# Altium schematic sheet USB.SchDoc
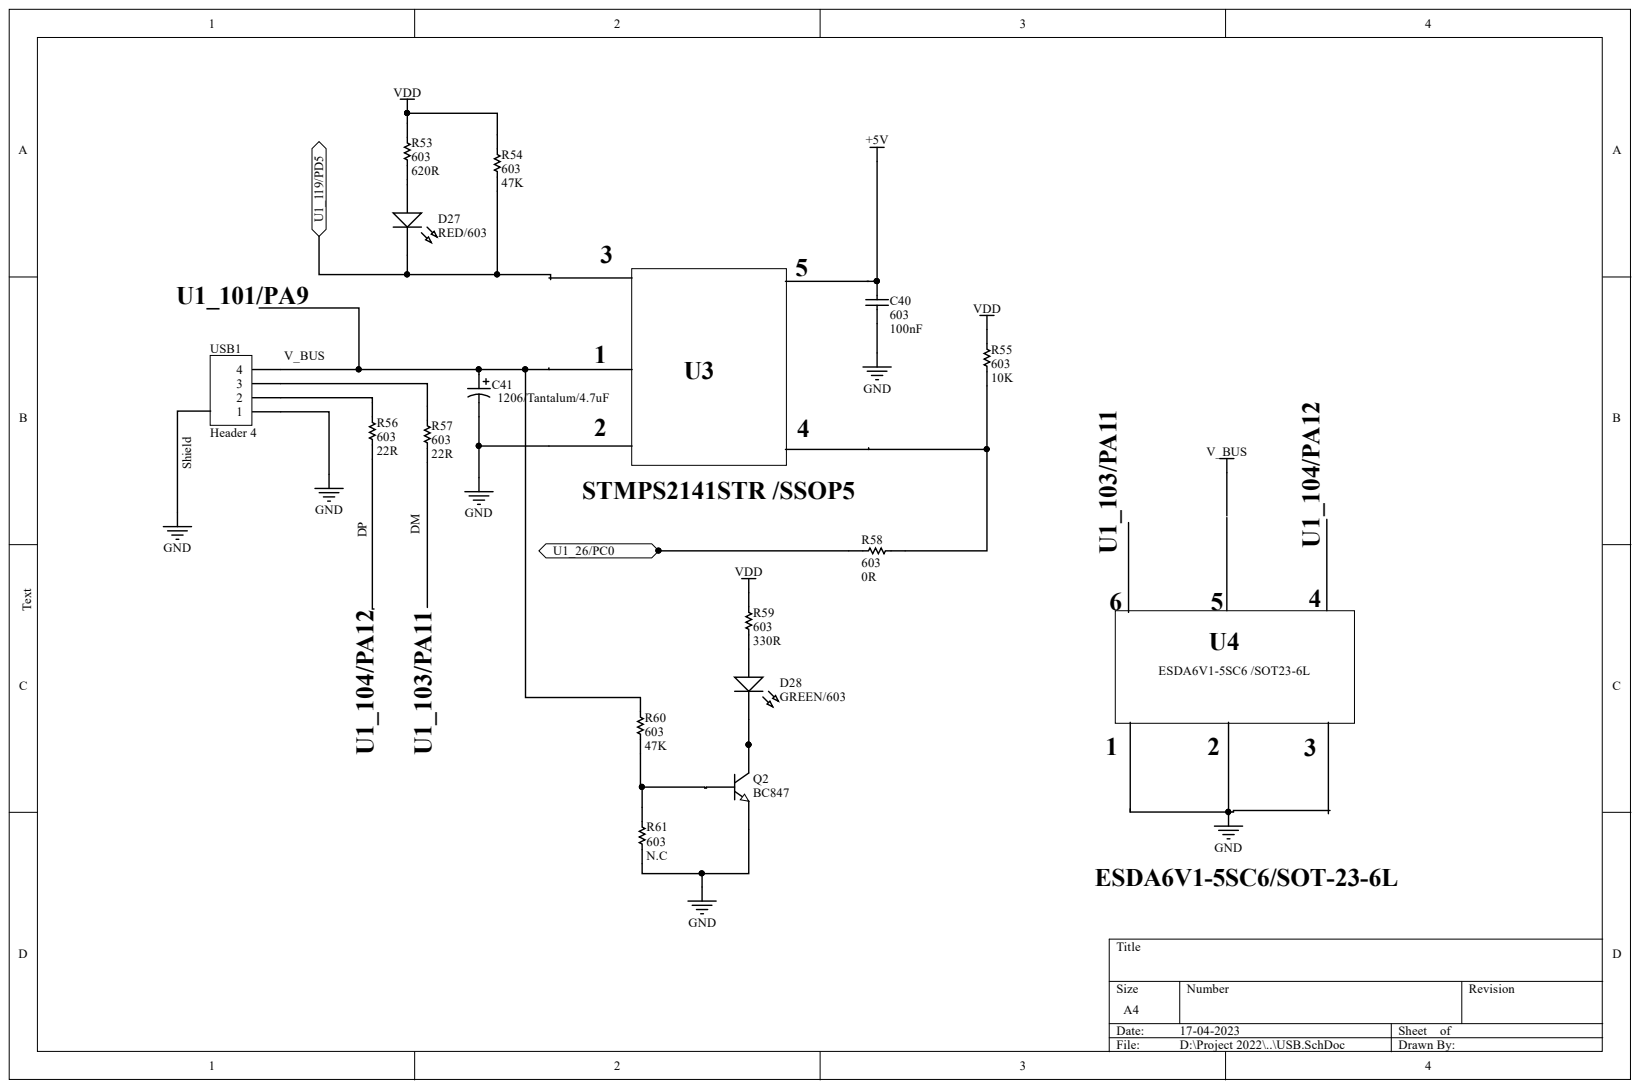
[diagram p0: USB.SchDoc]

## Parts
C40  603  value 100nF  [RAD-0.3]
C41  1206/Tantalum/4.7uF  [RB7.6-15]
D27  RED/603  [3.2X1.6X1.1]
D28  GREEN/603  [3.2X1.6X1.1]
Q2  BC847  [TO-226-AA]
R53  603  value 620R  [AXIAL-0.3]
R54  603  value 47K  [AXIAL-0.3]
R55  603  value 10K  [AXIAL-0.3]
R56  603  value 22R  [AXIAL-0.3]
R57  603  value 22R  [AXIAL-0.3]
R58  603  value 0R  [AXIAL-0.3]
R59  603  value 330R  [AXIAL-0.3]
R60  603  value 47K  [AXIAL-0.3]
R61  603  value N.C  [AXIAL-0.3]
USB1  Header 4  [HDR1X4]

## Ports
U1_26/PC0, U1_119/PD5

## Nets
+5V: C40.2
GND: C40.1 C41.2 Q2.3 R61.2 USB1.1
VDD: R53.2 R54.2 R55.2 R59.1
U1_26/PC0: R58.2 ; port U1_26/PC0
U1_119/PD5: D27.2 R54.1 ; port U1_119/PD5
NetC41_1: C41.1 R60.1 USB1.4
NetD27_1: D27.1 R53.1
NetD28_1: D28.1 R59.2
NetD28_2: D28.2 Q2.1
NetQ2_2: Q2.2 R60.2 R61.1
NetR55_1: R55.1 R58.1
NetR56_2: R56.2 USB1.2
NetR57_2: R57.2 USB1.3
V_BUS:

Not connected: R56 1; R57 1

## Library data of the parts
C40 = Cap: Capacitor; Component Kind: Standard; LatestRevisionDate: 17-Jul-2002; LatestRevisionNote: Re-released for DXP Platform.; Library Name: Miscellaneous Devices.IntLib; PackageDescription: Radial Cap, Thru-Hole; 2 Leads; 0.3 in Pin Spacing; PackageReference: RAD-0.3; Published: 8-Jun-2000; Signal Integrity: Cap; Simulation: CAP; Publisher: Altium Limited
C41 = Cap Pol1: Polarized Capacitor (Radial); Component Kind: Standard; LatestRevisionDate: 17-Jul-2002; LatestRevisionNote: Re-released for DXP Platform.; Library Name: Miscellaneous Devices.IntLib; PackageDescription: Polarized Capacitor (Radial); 2 Leads; PackageReference: RB7.6-15; Published: 8-Jun-2000; Signal Integrity: Cap Pol; Simulation: CAP; Publisher: Altium Limited
D27 D28 = LED2: Typical RED, GREEN, YELLOW, AMBER GaAs LED; Component Kind: Standard; LatestRevisionDate: 17-Jul-2002; LatestRevisionNote: Re-released for DXP Platform.; Library Name: Miscellaneous Devices.IntLib; PackageDescription: SM LED; 2 Flat Leads; PackageReference: 3.2X1.6X1.1; PackageVersion: Feb-2002; Published: 8-Jun-2000; Simulation: LED2; Publisher: Altium Limited
Q2 = NPN: NPN Bipolar Transistor; Code_JEDEC: TO-226-AA; Component Kind: Standard; LatestRevisionDate: 17-Jul-2002; LatestRevisionNote: Re-released for DXP Platform.; Library Name: Miscellaneous Devices.IntLib; PackageDescription: TO, Flat Index; 3 In-Line, Axial Leads; Body Dia. 4.8mm; Leads 0.55 x 0.51 mm (max); PackageReference: TO-226-AA; Published: 8-Jun-2000; Signal Integrity: NPN; Simulation: NPN; Publisher: Altium Limited
R53-R61 = Res1: Resistor; Component Kind: Standard; LatestRevisionDate: 17-Jul-2002; LatestRevisionNote: Re-released for DXP Platform.; Library Name: Miscellaneous Devices.IntLib; PackageDescription: Axial Device, Thru-Hole; 2 Leads; 0.3 in Pin Spacing; PackageReference: AXIAL-0.3; Published: 8-Jun-2000; Signal Integrity: Res; Simulation: RESISTOR; Publisher: Altium Limited
USB1 = Header 4: Header, 4-Pin; Component Kind: Standard; LatestRevisionDate: 17-Jul-2002; LatestRevisionNote: Re-released for DXP Platform.; Library Name: Miscellaneous Connectors.IntLib; Signal Integrity: Connector; Publisher: Altium Limited
